# Altium schematic sheet Sheet_Bott_Back.SchDoc
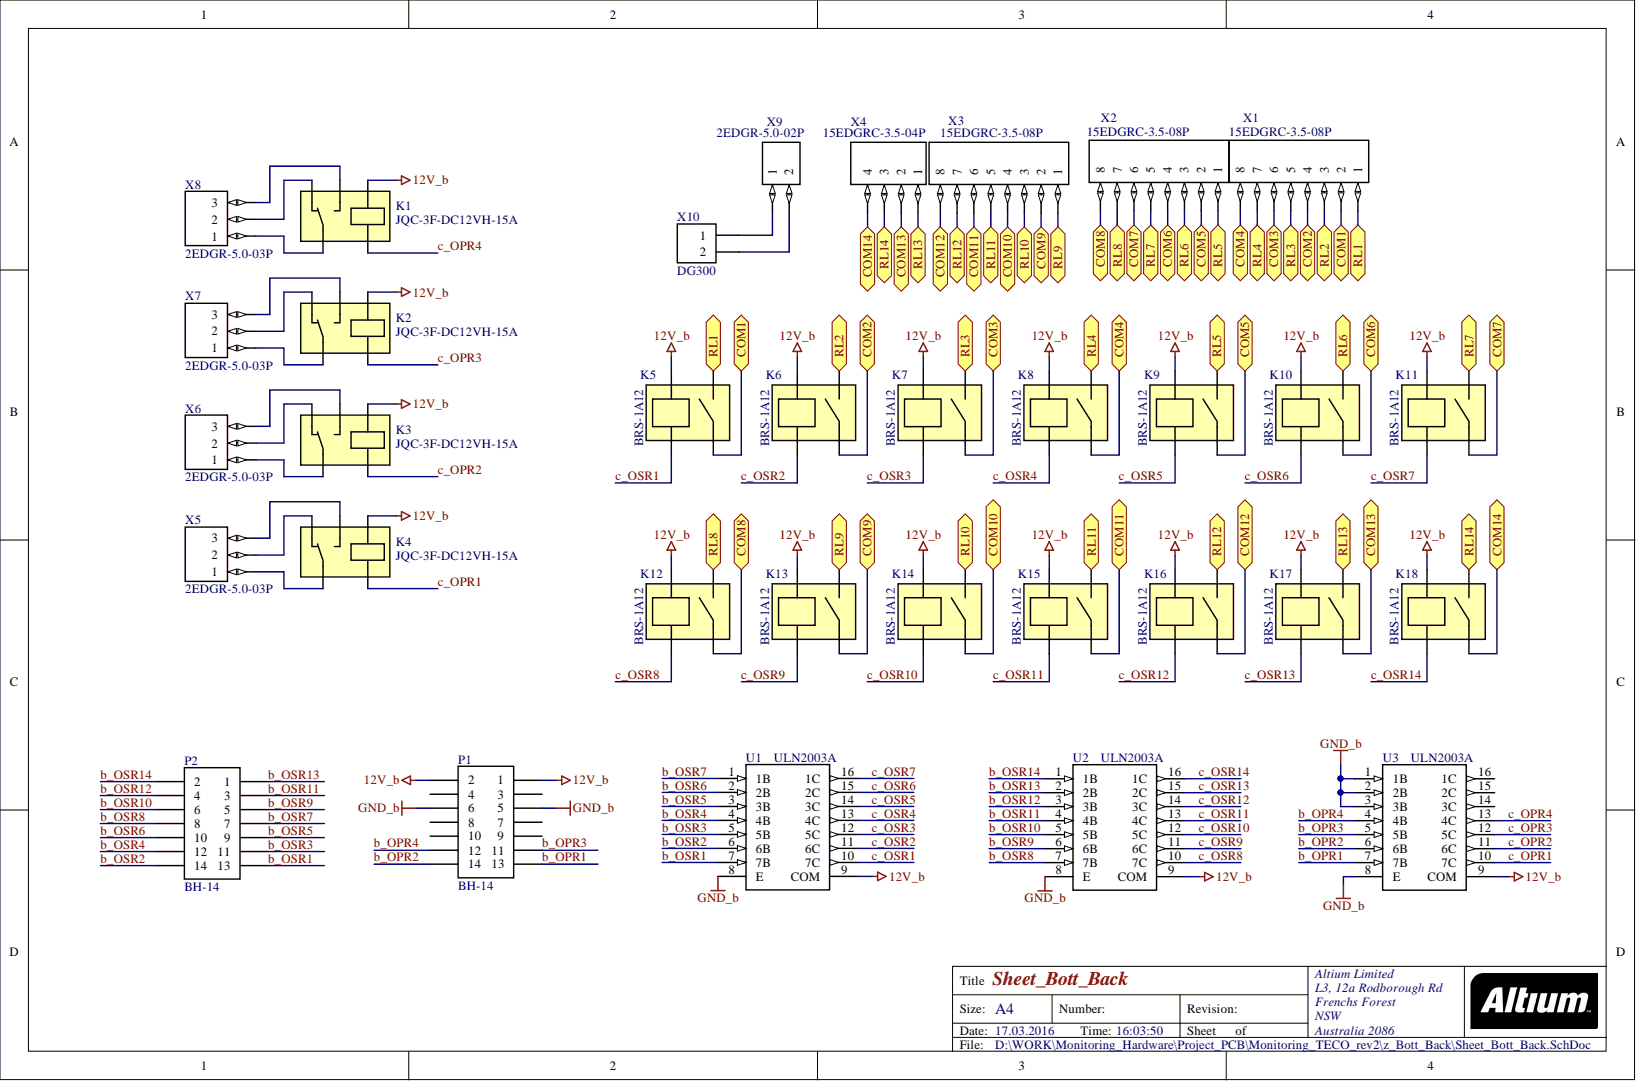
[diagram p0: Sheet_Bott_Back.SchDoc]

## Parts
K1  JQC-3F-DC12VH-15A  [BS-115C-12A]
K2  JQC-3F-DC12VH-15A  [BS-115C-12A]
K3  JQC-3F-DC12VH-15A  [BS-115C-12A]
K4  JQC-3F-DC12VH-15A  [BS-115C-12A]
K5  BRS-1A12  [R_BRS]
K6  BRS-1A12  [R_BRS]
K7  BRS-1A12  [R_BRS]
K8  BRS-1A12  [R_BRS]
K9  BRS-1A12  [R_BRS]
K10  BRS-1A12  [R_BRS]
K11  BRS-1A12  [R_BRS]
K12  BRS-1A12  [R_BRS]
K13  BRS-1A12  [R_BRS]
K14  BRS-1A12  [R_BRS]
K15  BRS-1A12  [R_BRS]
K16  BRS-1A12  [R_BRS]
K17  BRS-1A12  [R_BRS]
K18  BRS-1A12  [R_BRS]
P1  BH-14  [BH-14]
P2  BH-14  [BH-14]
U1  ULN2003A  [SO-16_N]
U2  ULN2003A  [SO-16_N]
U3  ULN2003A  [SO-16_N]
X1  15EDGRC-3.5-08P  [MC_3.5_8]
X2  15EDGRC-3.5-08P  [MC_3.5_8]
X3  15EDGRC-3.5-08P  [MC_3.5_8]
X4  15EDGRC-3.5-04P  [MC_3.5_4]
X5  2EDGR-5.0-03P  [2EDGR-5.0-03P]
X6  2EDGR-5.0-03P  [2EDGR-5.0-03P]
X7  2EDGR-5.0-03P  [2EDGR-5.0-03P]
X8  2EDGR-5.0-03P  [2EDGR-5.0-03P]
X9  2EDGR-5.0-02P  [2EDGR-5.0-02P]
X10  DG300  [5mm 2]

## Ports
COM1, COM2, COM3, COM4, COM5, COM6, COM7, COM8, COM9, COM10, COM11, COM12, COM13, COM14, RL1, RL2, RL3, RL4, RL5, RL6, RL7, RL8, RL9, RL10, RL11, RL12, RL13, RL14

## Nets
12V_B: K1.1 K2.1 K3.1 K4.1 K5.3 K6.3 K7.3 K8.3 K9.3 K10.3 K11.3 K12.3 K13.3 K14.3 K15.3 K16.3 K17.3 K18.3 P1.1 P1.2 U1.9(COM) U2.9(COM) U3.9(COM)
GND_B: P1.5 P1.6 U1.8(E) U2.8(E) U3.1(1B) U3.2(2B) U3.3(3B) U3.8(E)
B_OPR1: P1.13 U3.7(7B)
B_OPR2: P1.14 U3.6(6B)
B_OPR3: P1.11 U3.5(5B)
B_OPR4: P1.12 U3.4(4B)
B_OSR1: P2.13 U1.7(7B)
B_OSR2: P2.14 U1.6(6B)
B_OSR3: P2.11 U1.5(5B)
B_OSR4: P2.12 U1.4(4B)
B_OSR5: P2.9 U1.3(3B)
B_OSR6: P2.10 U1.2(2B)
B_OSR7: P2.7 U1.1(1B)
B_OSR8: P2.8 U2.7(7B)
B_OSR9: P2.5 U2.6(6B)
B_OSR10: P2.6 U2.5(5B)
B_OSR11: P2.3 U2.4(4B)
B_OSR12: P2.4 U2.3(3B)
B_OSR13: P2.1 U2.2(2B)
B_OSR14: P2.2 U2.1(1B)
C_OPR1: K4.2 U3.10(7C)
C_OPR2: K3.2 U3.11(6C)
C_OPR3: K2.2 U3.12(5C)
C_OPR4: K1.2 U3.13(4C)
C_OSR1: K5.5 U1.10(7C)
C_OSR2: K6.5 U1.11(6C)
C_OSR3: K7.5 U1.12(5C)
C_OSR4: K8.5 U1.13(4C)
C_OSR5: K9.5 U1.14(3C)
C_OSR6: K10.5 U1.15(2C)
C_OSR7: K11.5 U1.16(1C)
C_OSR8: K12.5 U2.10(7C)
C_OSR9: K13.5 U2.11(6C)
C_OSR10: K14.5 U2.12(5C)
C_OSR11: K15.5 U2.13(4C)
C_OSR12: K16.5 U2.14(3C)
C_OSR13: K17.5 U2.15(2C)
C_OSR14: K18.5 U2.16(1C)
COM1: K5.7 X1.2 ; port COM1
COM2: K6.7 X1.4 ; port COM2
COM3: K7.7 X1.6 ; port COM3
COM4: K8.7 X1.8 ; port COM4
COM5: K9.7 X2.2 ; port COM5
COM6: K10.7 X2.4 ; port COM6
COM7: K11.7 X2.6 ; port COM7
COM8: K12.7 X2.8 ; port COM8
COM9: K13.7 X3.2 ; port COM9
COM10: K14.7 X3.4 ; port COM10
COM11: K15.7 X3.6 ; port COM11
COM12: K16.7 X3.8 ; port COM12
COM13: K17.7 X4.2 ; port COM13
COM14: K18.7 X4.4 ; port COM14
RL1: K5.1 X1.1 ; port RL1
RL2: K6.1 X1.3 ; port RL2
RL3: K7.1 X1.5 ; port RL3
RL4: K8.1 X1.7 ; port RL4
RL5: K9.1 X2.1 ; port RL5
RL6: K10.1 X2.3 ; port RL6
RL7: K11.1 X2.5 ; port RL7
RL8: K12.1 X2.7 ; port RL8
RL9: K13.1 X3.1 ; port RL9
RL10: K14.1 X3.3 ; port RL10
RL11: K15.1 X3.5 ; port RL11
RL12: K16.1 X3.7 ; port RL12
RL13: K17.1 X4.1 ; port RL13
RL14: K18.1 X4.3 ; port RL14
NetK1_3: K1.3 X8.1
NetK1_4: K1.4 X8.2
NetK1_5: K1.5 X8.3
NetK2_3: K2.3 X7.1
NetK2_4: K2.4 X7.2
NetK2_5: K2.5 X7.3
NetK3_3: K3.3 X6.1
NetK3_4: K3.4 X6.2
NetK3_5: K3.5 X6.3
NetK4_3: K4.3 X5.1
NetK4_4: K4.4 X5.2
NetK4_5: K4.5 X5.3
NetX9_1: X9.1 X10.1
NetX9_2: X9.2 X10.2

Not connected: P1 3 4 7 8 9 10; U3 14(3C) 15(2C) 16(1C)

## Library data of the parts
K1-K4 = JQC-3F-DC12VH-7A: 7A 240Vac 1 pole Slim relay; Component Kind: Standard; Library Name: Relay.SchLib
K5-K18 = BRS-1A12: 1A, 10Vdc, 1 pole, SIP terminal; Component Kind: Standard; Library Name: Relay.SchLib
P1 P2 = BH-14: IDC/BH; Component Kind: Standard; LatestRevisionDate: 17-Jul-2002; LatestRevisionNote: Re-released for DXP Platform.; Library Name: Connect.SchLib; Publisher: Altium Limited
U1-U3 = ULN2003A: Darlington Transistor Arrays; Component Kind: Standard; Library Name: Chip_Packaging.SchLib
X1-X3 = MC_3.5_8: Connector 3.5mm; Component Kind: Standard; Library Name: Connect.SchLib
X4 = MC_3.5_4: Connector 3.5mm; Component Kind: Standard; Library Name: Connect.SchLib
X5-X8 = 2EDGR-5.0-03P: Connector 5mm; Component Kind: Standard; Library Name: Connect.SchLib
X9 = 2EDGR-5.0-02P: Connector 5mm; Component Kind: Standard; Library Name: Connect.SchLib
X10 = DG300_2pin: Connector 5mm; Component Kind: Standard; Library Name: Connect.SchLib
